# Altium schematic sheet IMX7S_SENSORS_&_EXTERNAL_INTERFACES.SchDoc
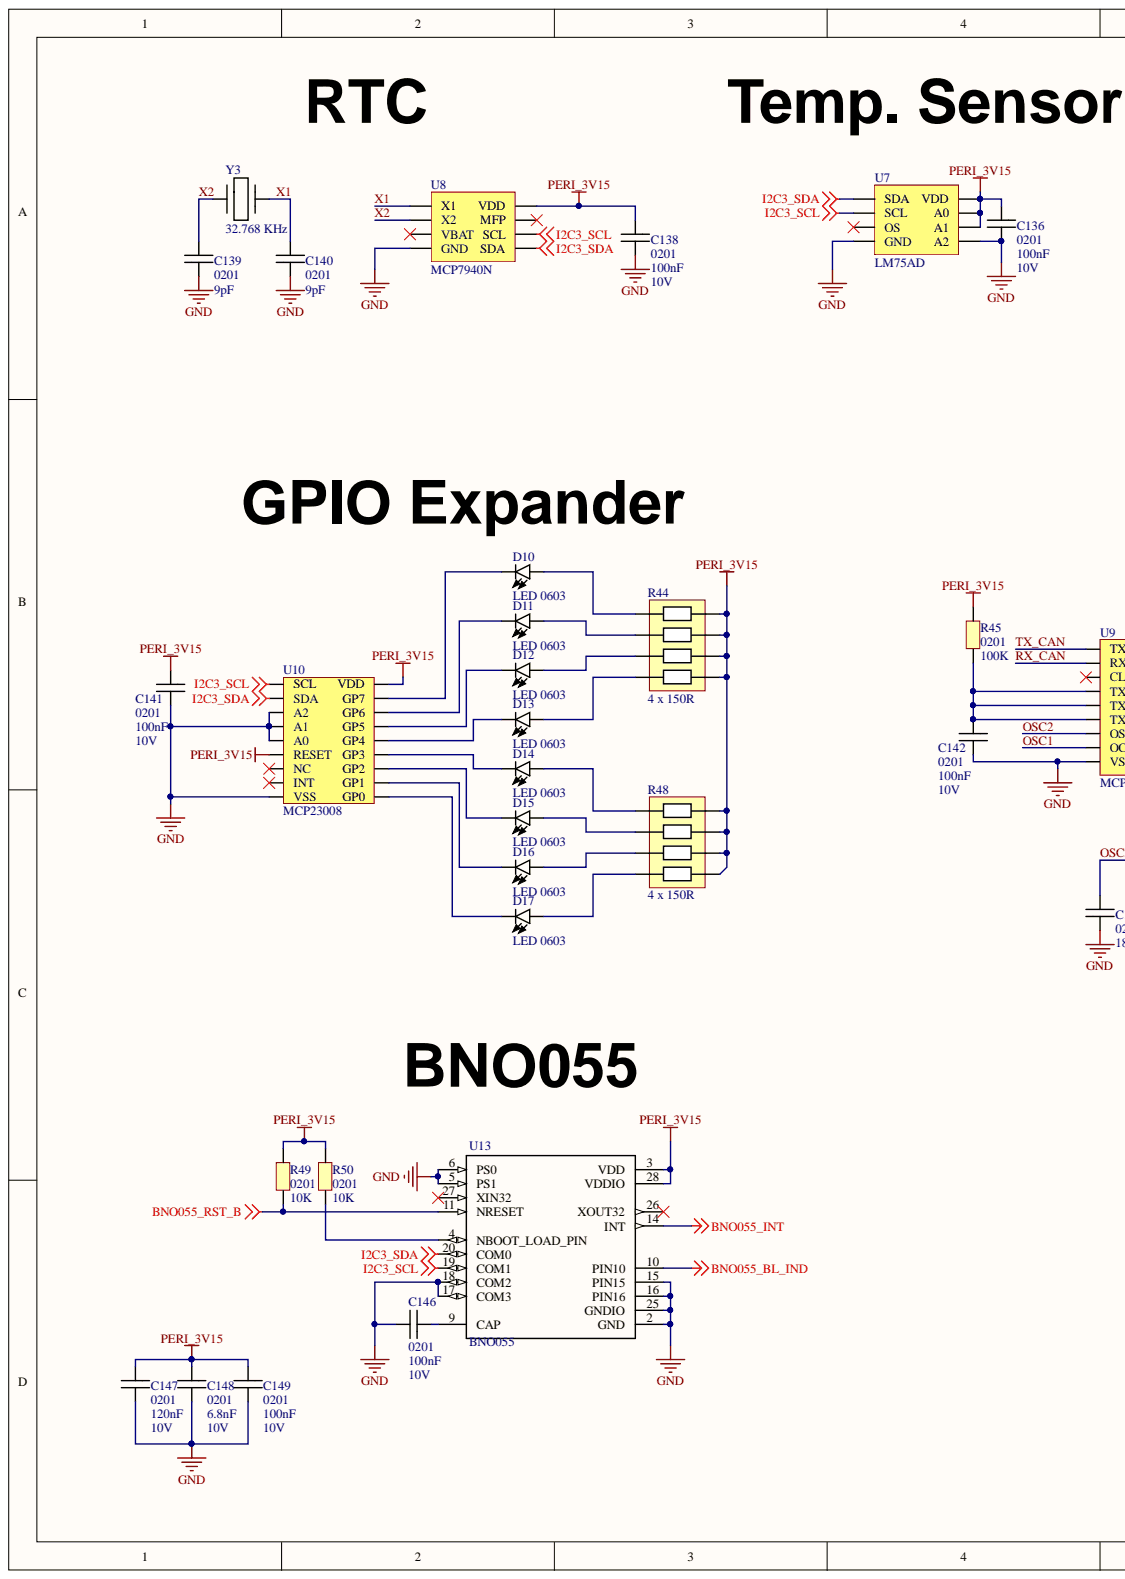
[diagram p0: IMX7S_SENSORS_&_EXTERNAL_INTERFACES.SchDoc, left]
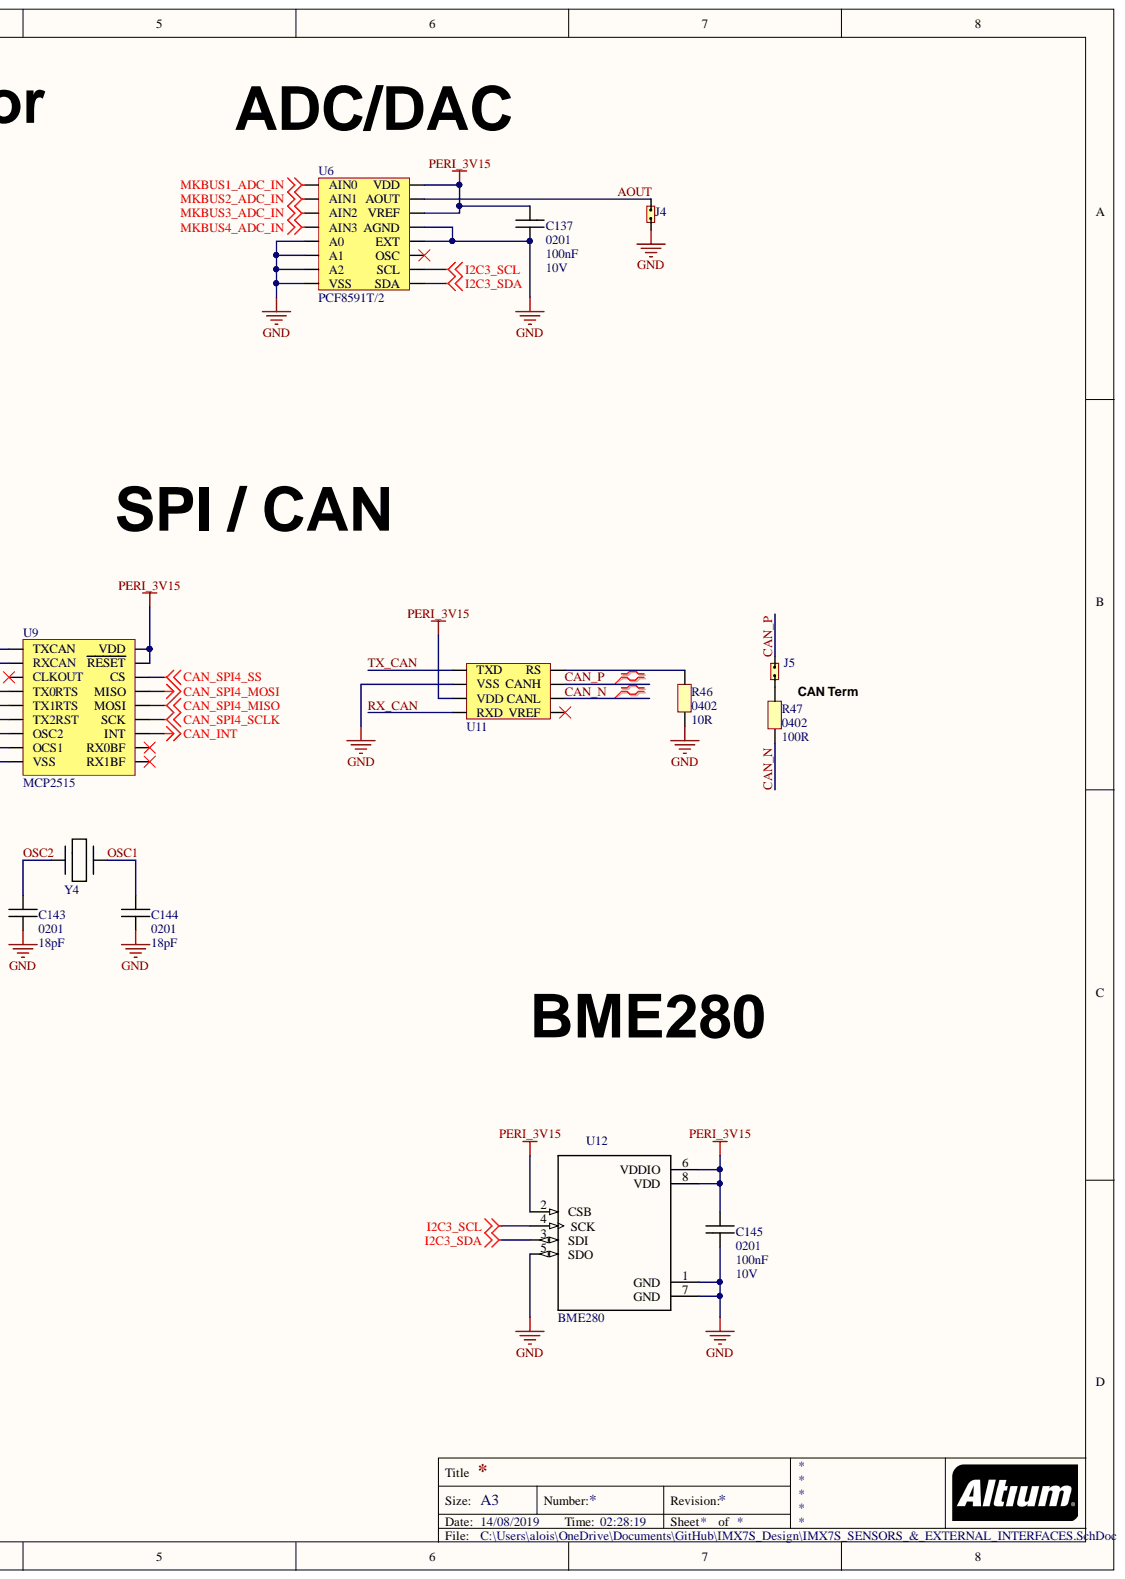
[diagram p1: IMX7S_SENSORS_&_EXTERNAL_INTERFACES.SchDoc, right]

## Parts
C136  value 100nF  PACKAGE: 0201  VOLTAGE: 10V  [C0201]  (hidden comment: C0201)
C137  value 100nF  PACKAGE: 0201  VOLTAGE: 10V  [C0201]  (hidden comment: C0201)
C138  value 100nF  PACKAGE: 0201  VOLTAGE: 10V  [C0201]  (hidden comment: C0201)
C139  value 9pF  PACKAGE: 0201  [C0201]  (hidden comment: C0201)
C140  value 9pF  PACKAGE: 0201  [C0201]  (hidden comment: C0201)
C141  value 100nF  PACKAGE: 0201  VOLTAGE: 10V  [C0201]  (hidden comment: C0201)
C142  value 100nF  PACKAGE: 0201  VOLTAGE: 10V  [C0201]  (hidden comment: C0201)
C143  value 18pF  PACKAGE: 0201  [C0201]  (hidden comment: C0201)
C144  value 18pF  PACKAGE: 0201  [C0201]  (hidden comment: C0201)
C145  value 100nF  PACKAGE: 0201  VOLTAGE: 10V  [C0201]  (hidden comment: C0201)
C146  value 100nF  PACKAGE: 0201  VOLTAGE: 10V  [C0201]  (hidden comment: C0201)
C147  value 120nF  PACKAGE: 0201  VOLTAGE: 10V  [C0201]  (hidden comment: C0201)
C148  value 6.8nF  PACKAGE: 0201  VOLTAGE: 10V  [C0201]  (hidden comment: C0201)
C149  value 100nF  PACKAGE: 0201  VOLTAGE: 10V  [C0201]  (hidden comment: C0201)
D10  LED 0603  [D0603]
D11  LED 0603  [D0603]
D12  LED 0603  [D0603]
D13  LED 0603  [D0603]
D14  LED 0603  [D0603]
D15  LED 0603  [D0603]
D16  LED 0603  [D0603]
D17  LED 0603  [D0603]
J4  Jumper  [Header 2]  (value not drawn)
J5  Jumper  [Header 2]  (value not drawn)
R44  4 x 150R  [Reseau_R_1206]
R45  value 100K  PACKAGE: 0201  [R0201]  (hidden comment: R0201)
R46  R0402  value 10R  PACKAGE: 0402  [R0402]
R47  R0402  value 100R  PACKAGE: 0402  [R0402]
R48  4 x 150R  [Reseau_R_1206]
R49  value 10K  PACKAGE: 0201  [R0201]  (hidden comment: R0201)
R50  value 10K  PACKAGE: 0201  [R0201]  (hidden comment: R0201)
U6  PCF8591T/2  [SOIC 16]
U7  LM75AD  [SOIC 8]
U8  MCP7940N  [SOIC 8]
U9  MCP2515  [SOIC 18]
U10  MCP23008  [SOIC 18]
U11  SN65HVD230DR  [SOIC 8]  (value not drawn)
U12  BME280  [PSON65P250X250X100-8N]
U13  BNO055  [LGA28R50P4X10_380X520X100]
Y3  32.768 KHz  [ABS07]
Y4  Quartz 8MHz  [HC49]  (value not drawn)

## Nets
BNO055_BL_IND: U13.10(PIN10)
BNO055_INT: U13.14(INT)
BNO055_RST_B: R49.1 U13.11(NRESET)
CAN_INT: U9.12(INT)
CAN_SPI4_MISO: U9.14(MOSI)
CAN_SPI4_MOSI: U9.15(MISO)
CAN_SPI4_SCLK: U9.13(SCK)
CAN_SPI4_SS: U9.16(CS)
GND: C136.2 C137.2 C138.2 C139.1 C140.1 C141.2 C142.2 C143.1 C144.1 C145.1 C146.2 C147.2 C148.2 C149.2 J4.1 R46.2 U6.5(A0) U6.6(A1) U6.7(A2) U6.8(VSS) U6.12(EXT) U6.13(AGND) U7.4(GND) U7.5(A2) U8.4(GND) U9.9(VSS) U10.3(A2) U10.4(A1) U10.5(A0) U10.9(VSS) U11.2(VSS) U12.1(GND) U12.5(SDO) U12.7(GND) U13.2(GND) U13.5(PS1) U13.6(PS0) U13.15(PIN15) U13.16(PIN16) U13.17(COM3) U13.18(COM2) U13.25(GNDIO)
I2C3_SCL: U6.10(SCL) U7.2(SCL) U8.6(SCL) U10.1(SCL) U12.4(SCK) U13.19(COM1)
I2C3_SDA: U6.9(SDA) U7.1(SDA) U8.5(SDA) U10.2(SDA) U12.3(SDI) U13.20(COM0)
MKBUS1_ADC_IN: U6.1(AIN0)
MKBUS2_ADC_IN: U6.2(AIN1)
MKBUS3_ADC_IN: U6.3(AIN2)
MKBUS4_ADC_IN: U6.4(AIN3)
PERI_3V15: C136.1 C137.1 C138.1 C141.1 C145.2 C147.1 C148.1 C149.1 R44.1 R44.2 R44.3 R44.4 R45.2 R48.1 R48.2 R48.3 R48.4 R49.2 R50.2 U6.14(VREF) U6.16(VDD) U7.6(A1) U7.7(A0) U7.8(VDD) U8.8(VDD) U9.17(RESET) U9.18(VDD) U10.6(RESET) U10.18(VDD) U11.3(VDD) U12.2(CSB) U12.6(VDDIO) U12.8(VDD) U13.3(VDD) U13.28(VDDIO)
AOUT: J4.2 U6.15(AOUT)
CAN_N: R47.1 U11.6(CANL)
CAN_P: J5.2 U11.7(CANH)
OSC1: C144.2 U9.8(OCS1) Y4.2
OSC2: C143.2 U9.7(OSC2) Y4.1
RX_CAN: U9.2(RXCAN) U11.4(RXD)
TX_CAN: U9.1(TXCAN) U11.1(TXD)
X1: C140.2 U8.1(X1) Y3.2
X2: C139.2 U8.2(X2) Y3.1
NetC142_1: C142.1 R45.1 U9.4(TX0RTS) U9.5(TX1RTS) U9.6(TX2RST)
NetC146_1: C146.1 U13.9(CAP)
NetD10_1: D10.1 R44.5
NetD10_2: D10.2 U10.17(GP7)
NetD11_1: D11.1 R44.6
NetD11_2: D11.2 U10.16(GP6)
NetD12_1: D12.1 R44.7
NetD12_2: D12.2 U10.15(GP5)
NetD13_1: D13.1 R44.8
NetD13_2: D13.2 U10.14(GP4)
NetD14_1: D14.1 R48.5
NetD14_2: D14.2 U10.13(GP3)
NetD15_1: D15.1 R48.6
NetD15_2: D15.2 U10.12(GP2)
NetD16_1: D16.1 R48.7
NetD16_2: D16.2 U10.11(GP1)
NetD17_1: D17.1 R48.8
NetD17_2: D17.2 U10.10(GP0)
NetJ5_1: J5.1 R47.2
NetR46_1: R46.1 U11.8(RS)
NetR50_1: R50.1 U13.4(NBOOT_LOAD_PIN)

Not connected: U6 11(OSC); U7 3(OS); U8 3(VBAT) 7(MFP); U9 3(CLKOUT) 10(RX1BF) 11(RX0BF); U10 7(NC) 8(INT); U11 5(VREF); U13 26(XOUT32) 27(XIN32)

## Library data of the parts
C139 C140 = C0201: VOLTAGE: 0V; Component Kind: Standard; Library Name: Schlib_IMX7.SchLib
C143 C144 = C0201: VOLTAGE: 10V; Component Kind: Standard; Library Name: Schlib_IMX7.SchLib
D10-D17 = LED: Component Kind: Standard; Library Name: Schlib_IMX7.SchLib
R44 R48 = Reseau_res: Component Kind: Standard; Library Name: Schlib_IMX7.SchLib
R45 R49 R50 = R0201: VOLTAGE: 0V; Component Kind: Standard; Library Name: Schlib_IMX7.SchLib
R46 R47 = R0402: VOLTAGE: 0V; Component Kind: Standard; Library Name: Schlib_IMX7.SchLib
U12 = BME280: Integrated pressure, humidity and temperature sensor; 8-pin 2.5x2.5x0.93mm LGA; MF: Bosch; Availability: Unavailable; Component Kind: Standard; Library Name: Schlib_IMX7.SchLib; MP: BME280; Package: LGA-8 Bosch; Price: None; SnapEDA_Link: https://www.snapeda.com/parts/BME280/Bosch%20Sensortec/view-part/?ref=snap
U13 = BNO055: BNO055 9-axis Absolute Orientation Sensor _ SiP _ w/Sensors and Sensor Fusion; MF: Bosch; Availability: Unavailable; Component Kind: Standard; Library Name: Schlib_IMX7.SchLib; MP: BNO055; Package: LGA-28 Bosch; Price: None; SnapEDA_Link: https://www.snapeda.com/parts/BNO055/Bosch%20Sensortec/view-part/?ref=snap
Y3 = Quartz 32.768: Component Kind: Standard; Library Name: Schlib_IMX7.SchLib; Supplier 1: Farnell; Supplier Part Number 1: 2819305
C136-C138 C141 C142 C145-C149 = C0201: Component Kind: Standard; Library Name: Schlib_IMX7.SchLib
J4 J5 = Jumper: Component Kind: Standard; Library Name: Schlib_IMX7.SchLib
U6 = PCF8591T/2: Component Kind: Standard; Library Name: Schlib_IMX7.SchLib
U7 = LM75AD: Component Kind: Standard; Library Name: Schlib_IMX7.SchLib
U8 = MCP7940N: Component Kind: Standard; Library Name: Schlib_IMX7.SchLib
U9 = MCP2515: Component Kind: Standard; Library Name: Schlib_IMX7.SchLib
U10 = MCP23008: Component Kind: Standard; Library Name: Schlib_IMX7.SchLib
U11 = SN65HVD230DR: Component Kind: Standard; Library Name: Schlib_IMX7.SchLib
Y4 = Quartz 8MHz: Component Kind: Standard; Library Name: Schlib_IMX7.SchLib; Supplier 1: Farnell; Supplier Part Number 1: 2467757
